# Altium schematic sheet StEth32_Base_OLED_UserIO.SchDoc
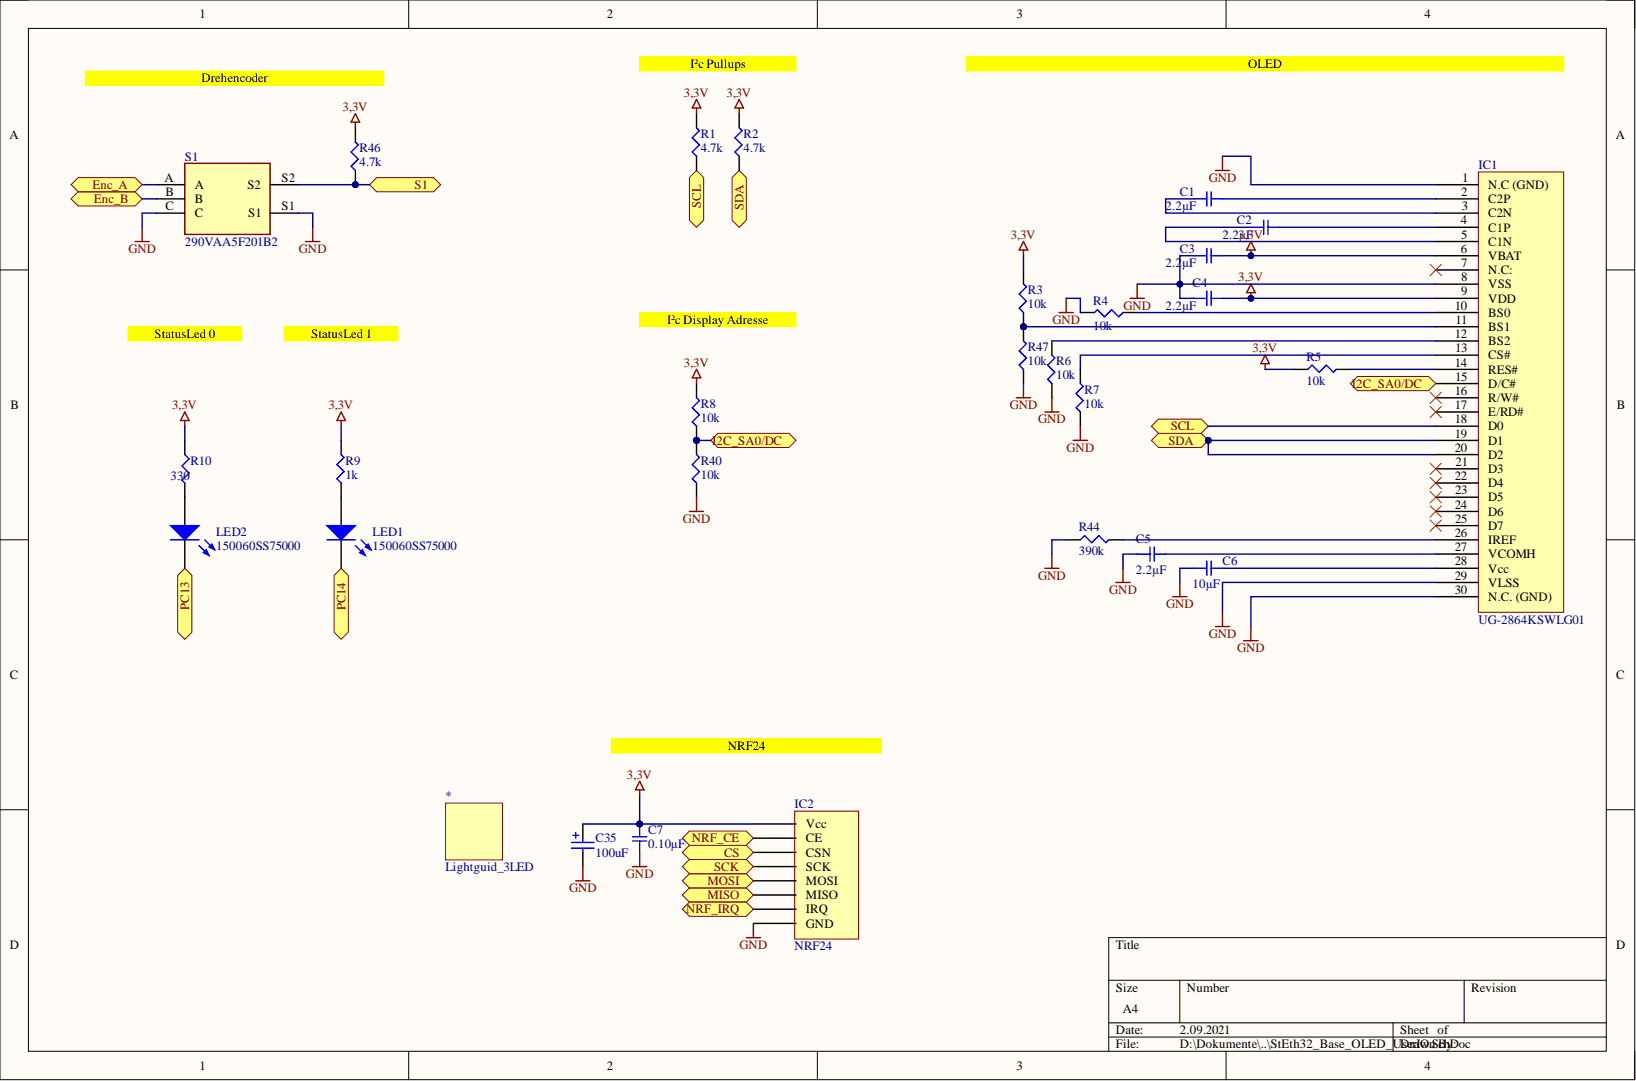
[diagram p0: StEth32_Base_OLED_UserIO.SchDoc]

## Parts
*  Lightguid_3LED  [LightGuid3]
C1  2.2µF  [SMD-0603C]
C2  2.2µF  [SMD-0603C]
C3  2.2µF  [SMD-0603C]
C4  2.2µF  [SMD-0603C]
C5  2.2µF  [SMD-0603C]
C6  10µF  [SMD-0603C]
C7  0.10µF  [SMD-0603C]
C35  value 100uF  [WCAP-ASLI_6.3x5.5]  (hidden comment: 865080243007)
IC1  UG-2864KSWLG01  [UG-2864KSWLG01]
IC2  NRF24  [ML01SP4]
LED1  150060SS75000  [0603_A]
LED2  150060SS75000  [0603_A]
R1  4.7k  [SMD-0603-RES]
R2  4.7k  [SMD-0603-RES]
R3  10k  [SMD-0603-RES]
R4  10k  [SMD-0603-RES]
R5  10k  [SMD-0603-RES]
R6  10k  [SMD-0603-RES]
R7  10k  [SMD-0603-RES]
R8  10k  [SMD-0603-RES]
R9  1k  [SMD-0603-RES]
R10  330  [SMD-0603-RES]
R40  10k  [SMD-0603-RES]
R44  390k  [SMD-0603-RES]
R46  4.7k  [SMD-0603-RES]
R47  10k  [SMD-0603-RES]
S1  290VAA5F201B2  [EC11B]

## Ports
CS, Enc_A, Enc_B, I2C_SA0/DC, MISO, MOSI, NRF_CE, NRF_IRQ, PC13, PC14, S1, SCK, SCL, SDA

## Nets
3,3V: C3.2 C4.2 C7.1 C35.1 IC1.6(VBAT) IC1.9(VDD) IC2.Vcc R1.2 R2.2 R3.2 R5.1 R8.2 R9.2 R10.1 R46.2
GND: C3.1 C4.1 C5.1 C6.1 C7.2 C35.2 IC1.1(N.C_(GND)) IC1.8(VSS) IC1.29(VLSS) IC1.30(N.C._(GND)) IC2.Gnd(GND) R4.2 R6.1 R7.1 R40.1 R44.1 R47.1 S1.C S1.S1
CS: IC2.CSN ; port CS
Enc_A: S1.A ; port Enc_A
Enc_B: S1.B ; port Enc_B
I2C_SA0/DC: IC1.15(D/C#) R8.1 R40.2 ; port I2C_SA0/DC
MISO: IC2.MISO ; port MISO
MOSI: IC2.MOSI ; port MOSI
NRF_CE: IC2.CE ; port NRF_CE
NRF_IRQ: IC2.IRQ ; port NRF_IRQ
PC13: LED2.1 ; port PC13
PC14: LED1.1 ; port PC14
S1: R46.1 S1.S2 ; port S1
SCK: IC2.SCK ; port SCK
SCL: IC1.18(D0) R1.1 ; port SCL
SDA: IC1.19(D1) IC1.20(D2) R2.1 ; port SDA
NetC1_1: C1.1 IC1.3(C2N)
NetC1_2: C1.2 IC1.2(C2P)
NetC2_1: C2.1 IC1.5(C1N)
NetC2_2: C2.2 IC1.4(C1P)
NetC5_2: C5.2 IC1.27(VCOMH)
NetC6_2: C6.2 IC1.28(Vcc)
NetIC1_10: IC1.10(BS0) R4.1
NetIC1_11: IC1.11(BS1) R3.1 R47.2
NetIC1_12: IC1.12(BS2) R6.2
NetIC1_13: IC1.13(CS#) R7.2
NetIC1_14: IC1.14(RES#) R5.2
NetIC1_26: IC1.26(IREF) R44.2
NetLED1_2: LED1.2 R9.1
NetLED2_2: LED2.2 R10.2

Not connected: IC1 7(N.C:) 16(R/W#) 17(E/RD#) 21(D3) 22(D4) 23(D5) 24(D6) 25(D7)

## Library data of the parts
* = Lightguid_3LED: Lichtleiter 3Dach 90°; Component Kind: Standard; Library Name: MyLibs.SchLib
C1-C5 = GRM188C81C225KA12D: CAP CER 2.2UF 16V X6S 0603; Manufacturer: Murata Electronics North America; @ qty: 0; Minimum Quantity: 1; Tolerance: ±10%; Unit Price (USD): 0.2; Applications: General Purpose; Component Kind: Standard; Datasheets: http://www.murata.com/~/media/webrenewal/support/library/catalog/products/capacitor/mlcc/c02e.pdf; Digi-Key Part Number: 490-11993-1-ND; Factory Stock: 0; Features: -; Height - Seated (Max): -; Image: //media.digikey.com/Renders/Murata%20Renders/ZRB-SERIES-0402.jpg; Lead Spacing: -; Lead Style: -; Library Name: capacitor_murata_0603.IntLib; Mounting Type: Surface Mount, MLCC; Operating Temperature: -55°C ~ 105°C; Package / Case: 0603 (1608 Metric); Packaging: Cut Tape (CT); Part Number: GRM188C81C225KA12D; Part Status: Active; Quantity Available: 1215; Ratings: -; Series: GRM; Size / Dimension: 0.063" L x 0.031" W (1.60mm x 0.80mm); Temperature Coefficient: X6S; Thickness (Max): 0.035" (0.90mm); Value: 2.2µF; Voltage: 16V
C6 = GRM185R60J106ME15D: CAP CER 10UF 6.3V X5R 0603; Manufacturer: Murata Electronics North America; @ qty: 0; Minimum Quantity: 1; Tolerance: ±20%; Unit Price (USD): 0.36; Applications: General Purpose; Component Kind: Standard; Datasheets: http://www.murata.com/~/media/webrenewal/support/library/catalog/products/capacitor/mlcc/c02e.pdf; Digi-Key Part Number: 490-10467-1-ND; Factory Stock: 0; Features: Derating Recommended; Height - Seated (Max): -; Image: //media.digikey.com/Renders/Murata%20Renders/ZRB-SERIES-0402.jpg; Lead Spacing: -; Lead Style: -; Library Name: capacitor_murata_0603.IntLib; Mounting Type: Surface Mount, MLCC; Operating Temperature: -55°C ~ 85°C; Package / Case: 0603 (1608 Metric); Packaging: Cut Tape (CT); Part Number: GRM185R60J106ME15D; Part Status: Active; Quantity Available: 25068; Ratings: -; Series: GRM; Size / Dimension: 0.063" L x 0.031" W (1.60mm x 0.80mm); Temperature Coefficient: X5R; Thickness (Max): 0.022" (0.55mm); Value: 10µF; Voltage: 6.3V
C7 = GCM188R71C104KA37J: CAP CER 0.1UF 16V X7R 0603; Manufacturer: Murata Electronics North America; @ qty: 0; Minimum Quantity: 1; Tolerance: ±10%; Unit Price (USD): 0.18; Applications: Automotive; Component Kind: Standard; Datasheets: http://www.murata.com/~/media/webrenewal/support/library/catalog/products/capacitor/mlcc/c03e.ashx; Digi-Key Part Number: 490-8015-1-ND; Factory Stock: 0; Features: -; Height - Seated (Max): -; Image: //media.digikey.com/Renders/Murata%20Renders/0603%28GCM188%29.jpg; Lead Spacing: -; Lead Style: -; Library Name: capacitor_murata_0603.IntLib; Mounting Type: Surface Mount, MLCC; Operating Temperature: -55°C ~ 125°C; Package / Case: 0603 (1608 Metric); Packaging: Cut Tape (CT); Part Number: GCM188R71C104KA37J; Part Status: Active; Quantity Available: 17844; Ratings: AEC-Q200; Series: GCM; Size / Dimension: 0.063" L x 0.031" W (1.60mm x 0.80mm); Temperature Coefficient: X7R; Thickness (Max): 0.035" (0.90mm); Value: 0.10µF; Voltage: 16V
C35 = 865080243007: WCAP-ASLI Aluminum Electrolytic Capacitors, size Ф6.3x5.5mm, 10V DC, 100uF; Rated Voltage: 10V DC; Component Kind: Standard; ComponentLink1Description: Manufacturer; ComponentLink1URL: http://www.we-online.de; ComponentLink2Description: Datasheet; ComponentLink2URL: http://katalog.we-online.de/pbs/datasheet/865080243007.pdf; Library Name: ALTIUM-WCAP-ASLI-rev17a.IntLib; Life Cycle: 2000h; Operating Temperature: -55 °C up to +105 °C; Part Number: 865080243007; Published Date: June 2017; Size: Ф6.3x5.5mm; Publisher: Wurth Elektronik
IC1 = UG-2864KSWLG01: OLED 1,3" 128x64; Component Kind: Standard; Library Name: MyLibs.SchLib
LED1 LED2 = 150060SS75000: SMD mono-color Chip LED, WL-SMCW, Super Red; Color: Super Red; Component Kind: Standard; ComponentLink1Description: Manufacturer URL; ComponentLink1URL: http://www.we-online.com; ComponentLink2Description: Datasheet; ComponentLink2URL: http://katalog.we-online.com/led/datasheet/150060SS75000.pdf; PackageReference: 0603_A; PartNumber: 150060SS75000; Library Name: WL-SMCW SMD chip LED top view mono-color waterclear.IntLib
R1 R2 R46 = ERJ-PA3F4701V: RES SMD 4.7K OHM 1% 1/4W 0603; Manufacturer: Panasonic Electronic Components; @ qty: 1500; Factory Stock: 0; Minimum Quantity: 1; Power (Watts): 0.25W, 1/4W; Temperature Coefficient: ±100ppm/°C; Unit Price (USD): 0.02372; Component Kind: Standard; Composition: Thick Film; Datasheets: https://industrial.panasonic.com/cdbs/www-data/pdf/RDA0000/AOA0000C243.pdf; Digi-Key Part Number: P4.7KBYCT-ND; Failure Rate: -; Features: Automotive AEC-Q200, Pulse Withstanding; Height - Seated (Max): 0.022" (0.55mm); Image: //media.digikey.com/Photos/Panasonic%20Photos/MFG_ERJ-P6W%20Series.jpg; Library Name: Panasonic_ERJ_0603.IntLib; Number of Terminations: 2; Operating Temperature: -55°C ~ 155°C; Package / Case: 0603 (1608 Metric); Packaging: Cut Tape (CT); Part Number: ERJ-PA3F4701V; Part Status: Active; Quantity Available: 14801; Series: ERJ; Size / Dimension: 0.063" L x 0.031" W (1.60mm x 0.80mm); Supplier Device Package: 0603; Tolerance: ±1%; Value: 4.7k
R3-R8 R40 R47 = ERJ-3EKF1002V: RES SMD 10K OHM 1% 1/10W 0603; Manufacturer: Panasonic Electronic Components; @ qty: 1500; Factory Stock: 0; Minimum Quantity: 1; Power (Watts): 0.1W, 1/10W; Temperature Coefficient: ±100ppm/°C; Unit Price (USD): 0.00379; Component Kind: Standard; Composition: Thick Film; Datasheets: http://industrial.panasonic.com/www-cgi/jvcr13pz.cgi?E+PZ+3+AOA0002+ERJ3EKF1002V+7+WW; Digi-Key Part Number: P10.0KHCT-ND; Failure Rate: -; Features: Automotive AEC-Q200; Height - Seated (Max): 0.022" (0.55mm); Image: //media.digikey.com/Photos/Panasonic%20Photos/MFG_ERJ-P6W%20Series.jpg; Library Name: Panasonic_ERJ_0603.IntLib; Number of Terminations: 2; Operating Temperature: -55°C ~ 155°C; Package / Case: 0603 (1608 Metric); Packaging: Cut Tape (CT); Part Number: ERJ-3EKF1002V; Part Status: Active; Quantity Available: 6703141; Series: ERJ; Size / Dimension: 0.063" L x 0.031" W (1.60mm x 0.80mm); Supplier Device Package: 0603; Tolerance: ±1%; Value: 10k
R9 = ERJ-3EKF1001V: RES SMD 1K OHM 1% 1/10W 0603; Manufacturer: Panasonic Electronic Components; @ qty: 1500; Factory Stock: 0; Minimum Quantity: 1; Power (Watts): 0.1W, 1/10W; Temperature Coefficient: ±100ppm/°C; Unit Price (USD): 0.00379; Component Kind: Standard; Composition: Thick Film; Datasheets: http://industrial.panasonic.com/www-cgi/jvcr13pz.cgi?E+PZ+3+AOA0002+ERJ3EKF1001V+7+WW; Digi-Key Part Number: P1.00KHCT-ND; Failure Rate: -; Features: Automotive AEC-Q200; Height - Seated (Max): 0.022" (0.55mm); Image: //media.digikey.com/Photos/Panasonic%20Photos/MFG_ERJ-P6W%20Series.jpg; Library Name: Panasonic_ERJ_0603.IntLib; Number of Terminations: 2; Operating Temperature: -55°C ~ 155°C; Package / Case: 0603 (1608 Metric); Packaging: Cut Tape (CT); Part Number: ERJ-3EKF1001V; Part Status: Active; Quantity Available: 5218845; Series: ERJ; Size / Dimension: 0.063" L x 0.031" W (1.60mm x 0.80mm); Supplier Device Package: 0603; Tolerance: ±1%; Value: 1k
R10 = ERJ-3EKF3300V: RES SMD 330 OHM 1% 1/10W 0603; Manufacturer: Panasonic Electronic Components; @ qty: 1500; Factory Stock: 0; Minimum Quantity: 1; Power (Watts): 0.1W, 1/10W; Temperature Coefficient: ±100ppm/°C; Unit Price (USD): 0.00379; Component Kind: Standard; Composition: Thick Film; Datasheets: http://industrial.panasonic.com/www-cgi/jvcr13pz.cgi?E+PZ+3+AOA0002+ERJ3EKF3300V+7+WW; Digi-Key Part Number: P330HCT-ND; Failure Rate: -; Features: Automotive AEC-Q200; Height - Seated (Max): 0.022" (0.55mm); Image: //media.digikey.com/Photos/Panasonic%20Photos/MFG_ERJ-P6W%20Series.jpg; Library Name: Panasonic_ERJ_0603.IntLib; Number of Terminations: 2; Operating Temperature: -55°C ~ 155°C; Package / Case: 0603 (1608 Metric); Packaging: Cut Tape (CT); Part Number: ERJ-3EKF3300V; Part Status: Active; Quantity Available: 670984; Series: ERJ; Size / Dimension: 0.063" L x 0.031" W (1.60mm x 0.80mm); Supplier Device Package: 0603; Tolerance: ±1%; Value: 330
R44 = ERJ-3EKF3903V: RES SMD 390K OHM 1% 1/10W 0603; Manufacturer: Panasonic Electronic Components; @ qty: 1500; Factory Stock: 0; Minimum Quantity: 1; Power (Watts): 0.1W, 1/10W; Temperature Coefficient: ±100ppm/°C; Unit Price (USD): 0.00379; Component Kind: Standard; Composition: Thick Film; Datasheets: http://industrial.panasonic.com/www-cgi/jvcr13pz.cgi?E+PZ+3+AOA0002+ERJ3EKF3903V+7+WW; Digi-Key Part Number: P390KHCT-ND; Failure Rate: -; Features: Automotive AEC-Q200; Height - Seated (Max): 0.022" (0.55mm); Image: //media.digikey.com/Photos/Panasonic%20Photos/MFG_ERJ-P6W%20Series.jpg; Library Name: Panasonic_ERJ_0603.IntLib; Number of Terminations: 2; Operating Temperature: -55°C ~ 155°C; Package / Case: 0603 (1608 Metric); Packaging: Cut Tape (CT); Part Number: ERJ-3EKF3903V; Part Status: Active; Quantity Available: 25618; Series: ERJ; Size / Dimension: 0.063" L x 0.031" W (1.60mm x 0.80mm); Supplier Device Package: 0603; Tolerance: ±1%; Value: 390k
S1 = 290VAA5F201B2: Switch; Manufacturer_Name: CTS; Component Kind: Standard; Datasheet Link: https://www.mouser.com/datasheet/2/96/290-1138413.pdf; Height: 26.7mm; Library Name: MyLibs.SchLib; Manufacturer_Part_Number: 290VAA5F201B2; Mouser Part Number: 774-290VAA5F201B2; Mouser Price/Stock: https://www.mouser.com/Search/Refine.aspx?Keyword=774-290VAA5F201B2; RS Part Number: 1774359; RS Price/Stock: http://uk.rs-online.com/web/p/products/1774359
IC2 = NRF24: Component Kind: Standard; Library Name: MyLibs.SchLib
